# Altium schematic sheet 6 Pole Butterworth Bandpass Filter.SchDoc("6 Pole Butterworth Bandpass Filter")
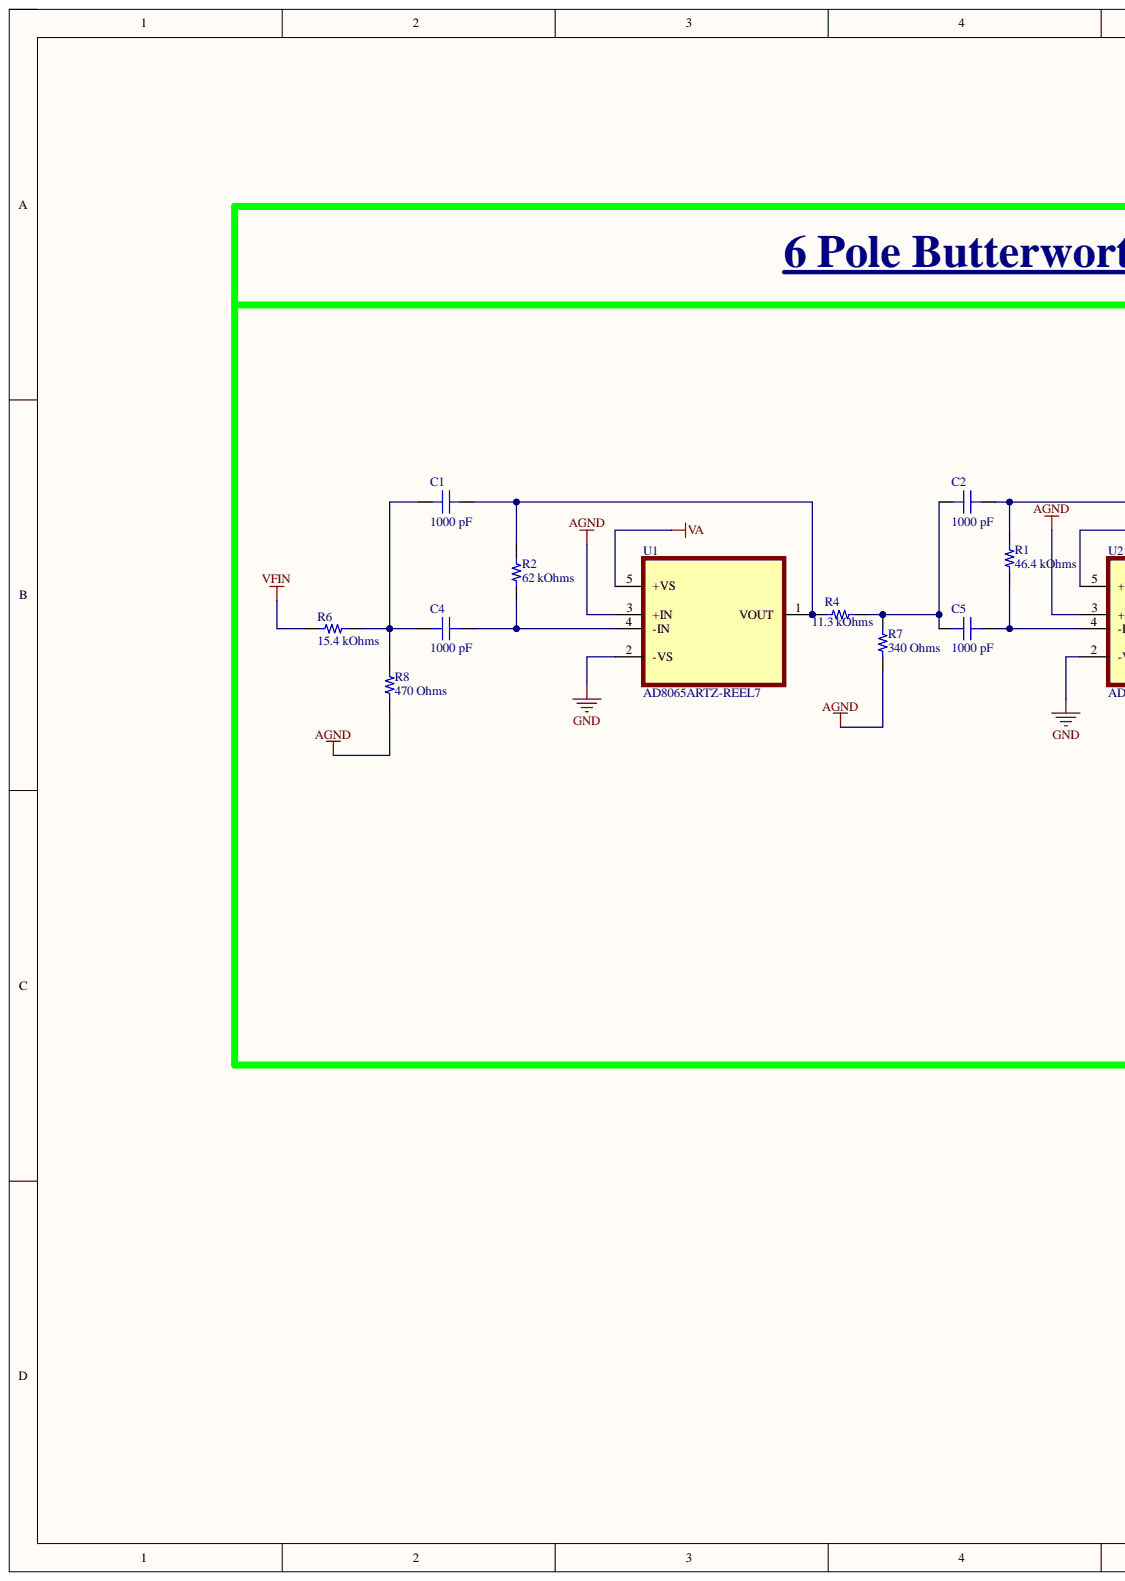
[diagram p0: 6 Pole Butterworth Bandpass Filter.SchDoc, left]
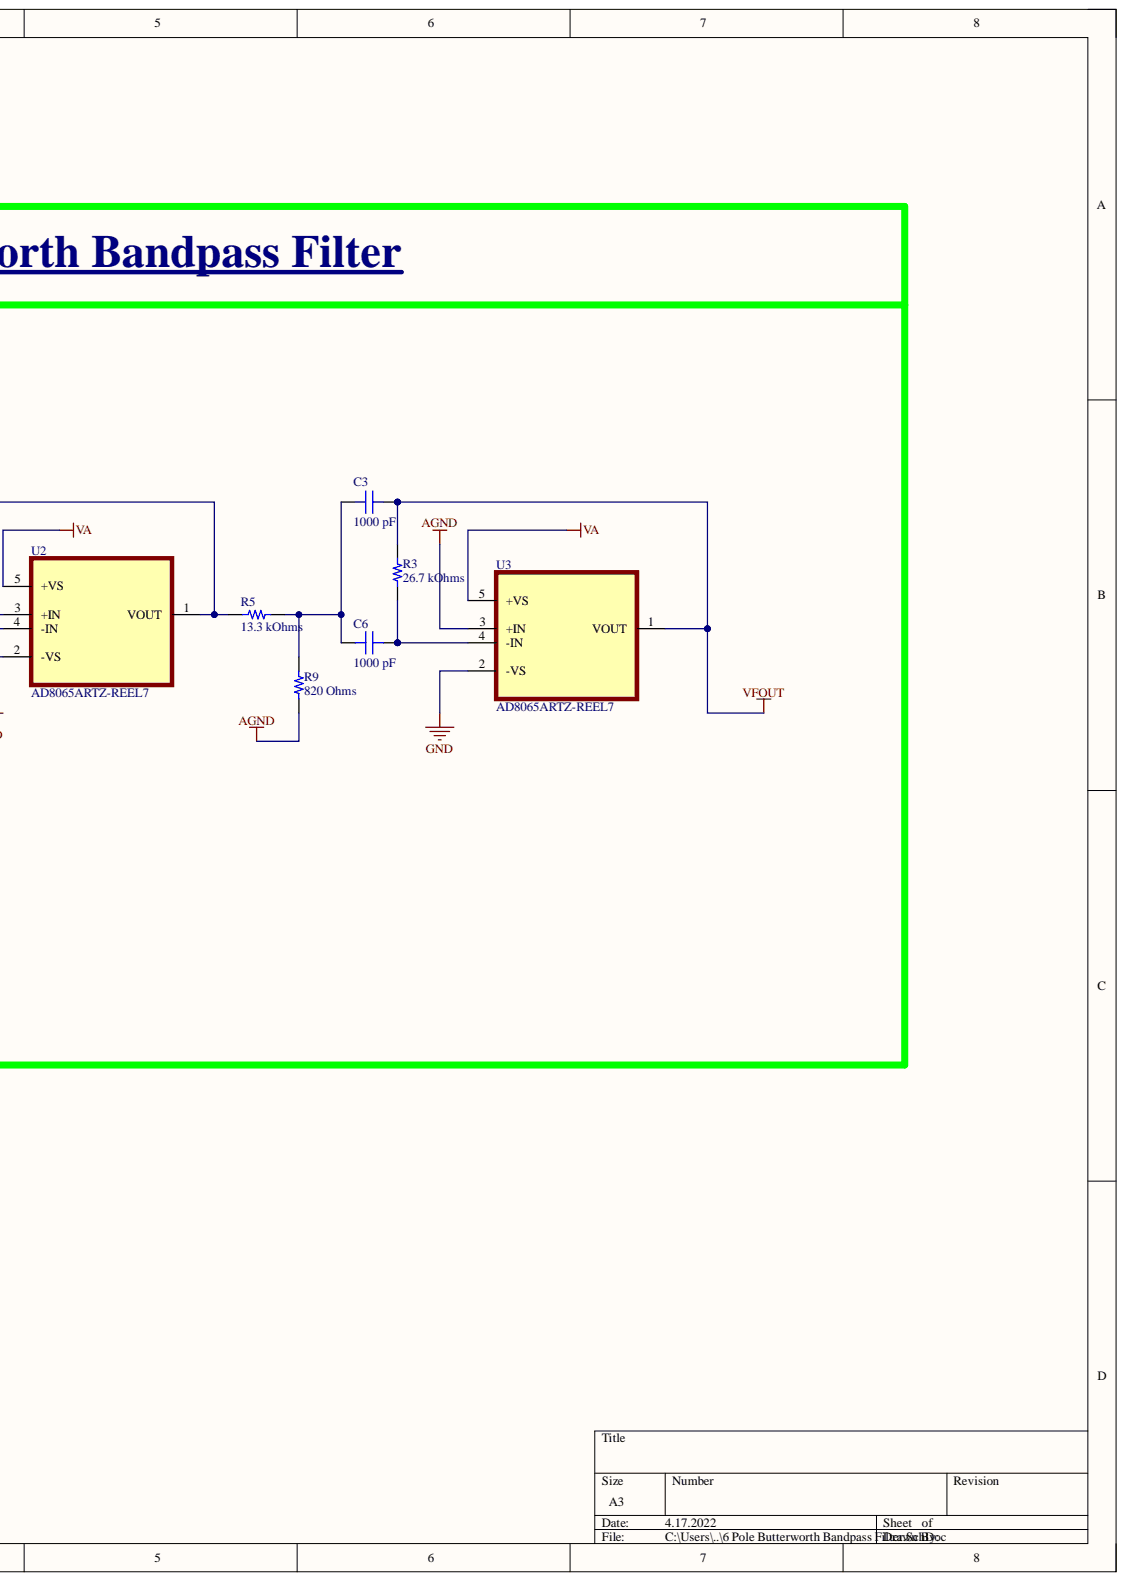
[diagram p1: 6 Pole Butterworth Bandpass Filter.SchDoc, right]

## Parts
C1  1000 pF  [CAP 0805_2012]
C2  1000 pF  [CAP 0805_2012]
C3  1000 pF  [CAP 0805_2012]
C4  1000 pF  [CAP 0805_2012]
C5  1000 pF  [CAP 0805_2012]
C6  1000 pF  [CAP 0805_2012]
R1  46.4 kOhms  [RES 0805_2012]
R2  62 kOhms  [RES 0805_2012]
R3  26.7 kOhms  [RES 0805_2012]
R4  11.3 kOhms  [RES 0805_2012]
R5  13.3 kOhms  [RES 1206_3216]
R6  15.4 kOhms  [RES 0805_2012]
R7  340 Ohms  [RES 1206_3216]
R8  470 Ohms  [RES 0805_2012]
R9  820 Ohms  [RES 0805_2012]
U1  AD8065ARTZ-REEL7  [SOT95P290X145-5N]
U2  AD8065ARTZ-REEL7  [SOT95P290X145-5N]
U3  AD8065ARTZ-REEL7  [SOT95P290X145-5N]

## Nets
AGND: R7.1 R8.1 R9.1 U1.3(+IN) U2.3(+IN) U3.3(+IN)
GND: U1.2(-VS) U2.2(-VS) U3.2(-VS)
VA: U1.5(+VS) U2.5(+VS) U3.5(+VS)
VFIN: R6.1
VFOUT: C3.2 R3.2 U3.1(VOUT)
NetC1_1: C1.1 C4.1 R6.2 R8.2
NetC1_2: C1.2 R2.2 R4.1 U1.1(VOUT)
NetC2_1: C2.1 C5.1 R4.2 R7.2
NetC2_2: C2.2 R1.2 R5.1 U2.1(VOUT)
NetC3_1: C3.1 C6.1 R5.2 R9.2
NetC4_2: C4.2 R2.1 U1.4(-IN)
NetC5_2: C5.2 R1.1 U2.4(-IN)
NetC6_2: C6.2 R3.1 U3.4(-IN)

## Library data of the parts
C1-C6 = C2012C0G2A102J060AA: CAP CER 1000PF 100V C0G 0805; manufacturer: TDK Corporation; Database Table Name: Capacitors - Ceramic - 0805; Temperature Coefficient: C0G, NP0; Tolerance: ±5%; Applications: General Purpose; Capacitance: 1000 pF; Component Kind: Standard; componentlink1description: Datasheet; componentlink1url: https://product.tdk.com/info/en/catalog/datasheets/mlcc_commercial_midvoltage_en.pdf?ref_disty=digikey; componentlink2description: DigiKey Link; componentlink2url: https://www.digikey.com/en/products/detail/tdk-corporation/C2012C0G2A102J060AA/789714; Device Package: 0805 (2012 Metric); lastupdated: 12.04.2022 22:11:39; Library Name: Celestial Altium Library - altium_library.DbLib; Lifecycle Status: Active; Minimum Order: 1; Mounting Type: Surface Mount, MLCC; Operating Temperature: -55°C ~ 125°C; Package / Case: 0805 (2012 Metric); packaging: TapeAndReel; Part Number: C2012C0G2A102J060AA; Part Status: Active; partid: 3238; price: 0.01; series: C; Size / Dimension: 0.079" L x 0.049" W (2.00mm x 1.25mm); Supplier 1: DigiKey; Supplier Part Number 1: 445-2320-1-ND; Thickness (Max): 0.030" (0.75mm); Value: 1000 pF; Voltage - Rated: 100V
R1 = RT0805DRE0746K4L: RES SMD 46.4K OHM 0.5% 1/8W 0805; Manufacturer: Yageo; Database Table Name: Resistors - Surface Mount - 0805; Power (Watts): 0.125W, 1/8W; Temperature Coefficient: ±50ppm/°C; Component Kind: Standard; ComponentLink1Description: Datasheet; ComponentLink1URL: https://www.yageo.com/upload/media/product/productsearch/datasheet/rchip/PYu-RT_1-to-0.01_RoHS_L_11.pdf; ComponentLink2Description: DigiKey Link; ComponentLink2URL: https://www.digikey.com/en/products/detail/yageo/RT0805DRE0746K4L/1078715; Composition: Thin Film; Device Package: 0805 (2012 Metric); Height - Seated (Max): 0.024" (0.60mm); LastUpdated: 12.04.2022 22:40:28; Library Name: Celestial Altium Library - altium_library.DbLib; Lifecycle Status: Active; Minimum Order: 1; Number of Terminations: 2; Operating Temperature: -55°C ~ 155°C; Package / Case: 0805 (2012 Metric); Packaging: TapeAndReel; Part Number: RT0805DRE0746K4L; Part Status: Active; PartId: 31477; Price: 0.01; Resistance: 46.4 kOhms; Series: RT; Size / Dimension: 0.079" L x 0.049" W (2.00mm x 1.25mm); Supplier 1: DigiKey; Supplier Device Package: 0805; Supplier Part Number 1: 311-2866-1-ND; Tolerance: ±0.5%; Value: 46.4 kOhms
R2 = CPF0805B62KE1: RES SMD 62K OHM 0.1% 1/10W 0805; Manufacturer: TE Connectivity Passive Product; Database Table Name: Resistors - Surface Mount - 0805; Power (Watts): 0.1W, 1/10W; Temperature Coefficient: ±25ppm/°C; Component Kind: Standard; ComponentLink1Description: Datasheet; ComponentLink1URL: https://www.te.com/commerce/DocumentDelivery/DDEController?Action=srchrtrv&DocNm=1773200&DocType=DS&DocLang=English; ComponentLink2Description: DigiKey Link; ComponentLink2URL: https://www.digikey.com/en/products/detail/te-connectivity-passive-product/CPF0805B62KE1/2382068; Composition: Thin Film; Device Package: 0805 (2012 Metric); Height - Seated (Max): 0.026" (0.65mm); LastUpdated: 12.04.2022 22:40:19; Library Name: Celestial Altium Library - altium_library.DbLib; Lifecycle Status: Active; Minimum Order: 1; Number of Terminations: 2; Operating Temperature: -55°C ~ 155°C; Package / Case: 0805 (2012 Metric); Packaging: TapeAndReel; Part Number: CPF0805B62KE1; Part Status: Active; PartId: 34241; Price: 0.13; Resistance: 62 kOhms; Series: CPF, Neohm; Size / Dimension: 0.079" L x 0.049" W (2.00mm x 1.25mm); Supplier 1: DigiKey; Supplier Device Package: 0805; Supplier Part Number 1: A103230CT-ND; Tolerance: ±0.1%; Value: 62 kOhms
R3 = ERJ-6ENF2672V: RES SMD 26.7K OHM 1% 1/8W 0805; Manufacturer: Panasonic Electronic Components; Database Table Name: Resistors - Surface Mount - 0805; Power (Watts): 0.125W, 1/8W; Temperature Coefficient: ±100ppm/°C; Component Kind: Standard; ComponentLink1Description: Datasheet; ComponentLink1URL: https://industrial.panasonic.com/cdbs/www-data/pdf/RDA0000/AOA0000C304.pdf; ComponentLink2Description: DigiKey Link; ComponentLink2URL: https://www.digikey.com/en/products/detail/panasonic-electronic-components/ERJ-6ENF2672V/111557; Composition: Thick Film; Device Package: 0805 (2012 Metric); Features: Automotive AEC-Q200; Height - Seated (Max): 0.028" (0.70mm); LastUpdated: 12.04.2022 22:40:32; Library Name: Celestial Altium Library - altium_library.DbLib; Lifecycle Status: Active; Minimum Order: 1; Number of Terminations: 2; Operating Temperature: -55°C ~ 155°C; Package / Case: 0805 (2012 Metric); Packaging: TapeAndReel; Part Number: ERJ-6ENF2672V; Part Status: Active; PartId: 30267; Price: 0.01; Ratings: AEC-Q200; Resistance: 26.7 kOhms; Series: ERJ-6EN; Size / Dimension: 0.079" L x 0.049" W (2.00mm x 1.25mm); Supplier 1: DigiKey; Supplier Device Package: 0805; Supplier Part Number 1: P26.7KCCT-ND; Tolerance: ±1%; Value: 26.7 kOhms
R4 = RK73H2ATTD1132F: RES 11.3K OHM 1% 1/4W 0805; Manufacturer: KOA Speer Electronics, Inc.; Database Table Name: Resistors - Surface Mount - 0805; Power (Watts): 0.25W, 1/4W; Temperature Coefficient: ±100ppm/°C; Component Kind: Standard; ComponentLink1Description: Datasheet; ComponentLink1URL: http://www.koaspeer.com/catimages/Products/RK73H/RK73H.pdf; ComponentLink2Description: DigiKey Link; ComponentLink2URL: https://www.digikey.com/en/products/detail/koa-speer-electronics-inc/RK73H2ATTD1132F/10233627; Composition: Thick Film; Device Package: 0805 (2012 Metric); Features: Automotive AEC-Q200, Moisture Resistant; Height - Seated (Max): 0.024" (0.60mm); LastUpdated: 12.04.2022 22:40:20; Library Name: Celestial Altium Library - altium_library.DbLib; Lifecycle Status: Active; Minimum Order: 1; Number of Terminations: 2; Operating Temperature: -55°C ~ 155°C; Package / Case: 0805 (2012 Metric); Packaging: TapeAndReel; Part Number: RK73H2ATTD1132F; Part Status: Active; PartId: 33915; Price: 0.01; Ratings: AEC-Q200; Resistance: 11.3 kOhms; Series: RK73H; Size / Dimension: 0.079" L x 0.049" W (2.00mm x 1.25mm); Supplier 1: DigiKey; Supplier Device Package: 0805; Supplier Part Number 1: 2019-RK73H2ATTD1132FCT-ND; Tolerance: ±1%; Value: 11.3 kOhms
R5 = RK73H2BTTD1332F: RES 13.3K OHM 1% 1/4W 1206; Manufacturer: KOA Speer Electronics, Inc.; Database Table Name: Resistors - Surface Mount - 1206; Power (Watts): 0.25W, 1/4W; Temperature Coefficient: ±100ppm/°C; Component Kind: Standard; ComponentLink1Description: Datasheet; ComponentLink1URL: http://www.koaspeer.com/catimages/Products/RK73H/RK73H.pdf; ComponentLink2Description: DigiKey Link; ComponentLink2URL: https://www.digikey.com/en/products/detail/koa-speer-electronics-inc/RK73H2BTTD1332F/10236178; Composition: Thick Film; Device Package: 1206 (3216 Metric); Features: Automotive AEC-Q200, Moisture Resistant; Height - Seated (Max): 0.028" (0.70mm); LastUpdated: 12.04.2022 22:34:22; Library Name: Celestial Altium Library - altium_library.DbLib; Lifecycle Status: Active; Minimum Order: 1; Number of Terminations: 2; Operating Temperature: -55°C ~ 155°C; Package / Case: 1206 (3216 Metric); Packaging: TapeAndReel; Part Number: RK73H2BTTD1332F; Part Status: Active; PartId: 27243; Price: 0.01; Ratings: AEC-Q200; Resistance: 13.3 kOhms; Series: RK73H; Size / Dimension: 0.126" L x 0.063" W (3.20mm x 1.60mm); Supplier 1: DigiKey; Supplier Device Package: 1206; Supplier Part Number 1: 2019-RK73H2BTTD1332FCT-ND; Tolerance: ±1%; Value: 13.3 kOhms
R6 = ERJ-6ENF1542V: RES SMD 15.4K OHM 1% 1/8W 0805; Manufacturer: Panasonic Electronic Components; Database Table Name: Resistors - Surface Mount - 0805; Power (Watts): 0.125W, 1/8W; Temperature Coefficient: ±100ppm/°C; Component Kind: Standard; ComponentLink1Description: Datasheet; ComponentLink1URL: https://industrial.panasonic.com/cdbs/www-data/pdf/RDA0000/AOA0000C304.pdf; ComponentLink2Description: DigiKey Link; ComponentLink2URL: https://www.digikey.com/en/products/detail/panasonic-electronic-components/ERJ-6ENF1542V/111510; Composition: Thick Film; Device Package: 0805 (2012 Metric); Features: Automotive AEC-Q200; Height - Seated (Max): 0.028" (0.70mm); LastUpdated: 12.04.2022 22:40:33; Library Name: Celestial Altium Library - altium_library.DbLib; Lifecycle Status: Active; Minimum Order: 1; Number of Terminations: 2; Operating Temperature: -55°C ~ 155°C; Package / Case: 0805 (2012 Metric); Packaging: TapeAndReel; Part Number: ERJ-6ENF1542V; Part Status: Active; PartId: 30250; Price: 0.01; Ratings: AEC-Q200; Resistance: 15.4 kOhms; Series: ERJ-6EN; Size / Dimension: 0.079" L x 0.049" W (2.00mm x 1.25mm); Supplier 1: DigiKey; Supplier Device Package: 0805; Supplier Part Number 1: P15.4KCCT-ND; Tolerance: ±1%; Value: 15.4 kOhms
R7 = ERJ-8ENF3400V: RES SMD 340 OHM 1% 1/4W 1206; Manufacturer: Panasonic Electronic Components; Database Table Name: Resistors - Surface Mount - 1206; Power (Watts): 0.25W, 1/4W; Temperature Coefficient: ±100ppm/°C; Component Kind: Standard; ComponentLink1Description: Datasheet; ComponentLink1URL: https://industrial.panasonic.com/cdbs/www-data/pdf/RDA0000/AOA0000C304.pdf; ComponentLink2Description: DigiKey Link; ComponentLink2URL: https://www.digikey.com/en/products/detail/panasonic-electronic-components/ERJ-8ENF3400V/88773; Composition: Thick Film; Device Package: 1206 (3216 Metric); Features: Automotive AEC-Q200; Height - Seated (Max): 0.028" (0.70mm); LastUpdated: 12.04.2022 22:34:11; Library Name: Celestial Altium Library - altium_library.DbLib; Lifecycle Status: Active; Minimum Order: 1; Number of Terminations: 2; Operating Temperature: -55°C ~ 155°C; Package / Case: 1206 (3216 Metric); Packaging: TapeAndReel; Part Number: ERJ-8ENF3400V; Part Status: Active; PartId: 29324; Price: 0.02; Ratings: AEC-Q200; Resistance: 340 Ohms; Series: ERJ-8EN; Size / Dimension: 0.126" L x 0.063" W (3.20mm x 1.60mm); Supplier 1: DigiKey; Supplier Device Package: 1206; Supplier Part Number 1: P340FCT-ND; Tolerance: ±1%; Value: 340 Ohms
R8 = CRGP0805F470R: RES 470 OHM 1% 1/3W 0805; Manufacturer: TE Connectivity Passive Product; Database Table Name: Resistors - Surface Mount - 0805; Power (Watts): 0.333W, 1/3W; Temperature Coefficient: ±100ppm/°C; Component Kind: Standard; ComponentLink1Description: Datasheet; ComponentLink1URL: https://www.te.com/commerce/DocumentDelivery/DDEController?Action=srchrtrv&DocNm=9-1773463-9&DocType=DS&DocLang=English; ComponentLink2Description: DigiKey Link; ComponentLink2URL: https://www.digikey.com/en/products/detail/te-connectivity-passive-product/CRGP0805F470R/8577075; Composition: Thick Film; Device Package: 0805 (2012 Metric); Features: Pulse Withstanding; Height - Seated (Max): 0.026" (0.65mm); LastUpdated: 12.04.2022 22:40:38; Library Name: Celestial Altium Library - altium_library.DbLib; Lifecycle Status: Active; Minimum Order: 1; Number of Terminations: 2; Operating Temperature: -55°C ~ 155°C; Package / Case: 0805 (2012 Metric); Packaging: TapeAndReel; Part Number: CRGP0805F470R; Part Status: Active; PartId: 28801; Price: 0.03; Resistance: 470 Ohms; Series: CRGP; Size / Dimension: 0.079" L x 0.049" W (2.00mm x 1.25mm); Supplier 1: DigiKey; Supplier Device Package: 0805; Supplier Part Number 1: A130473CT-ND; Tolerance: ±1%; Value: 470 Ohms
R9 = CR0805-JW-821EPF: RES 0805 820R 5% 125MW TC200 LOW; Manufacturer: Bourns Inc.; Database Table Name: Resistors - Surface Mount - 0805; Power (Watts): 0.125W, 1/8W; Temperature Coefficient: ±200ppm/°C; Component Kind: Standard; ComponentLink1Description: Datasheet; ComponentLink1URL: https://www.bourns.com/docs/Product-Datasheets/CR-PF.pdf; ComponentLink2Description: DigiKey Link; ComponentLink2URL: https://www.digikey.com/en/products/detail/bourns-inc/CR0805-JW-821EPF/8572952; Composition: Thick Film; Device Package: 0805 (2012 Metric); Height - Seated (Max): 0.024" (0.60mm); LastUpdated: 12.04.2022 22:39:27; Library Name: Celestial Altium Library - altium_library.DbLib; Lifecycle Status: Active; Minimum Order: 1; Number of Terminations: 2; Operating Temperature: -55°C ~ 155°C; Package / Case: 0805 (2012 Metric); Packaging: TapeAndReel; Part Number: CR0805-JW-821EPF; Part Status: Active; PartId: 46969; Price: 0.01; Resistance: 820 Ohms; Series: CR-PF; Size / Dimension: 0.079" L x 0.049" W (2.00mm x 1.25mm); Supplier 1: DigiKey; Supplier Device Package: 0805; Supplier Part Number 1: CR0805-JW-821EPFCT-ND; Tolerance: ±5%; Value: 820 Ohms
U1-U3 = AD8065ARTZ-REEL7: Voltage Feedback Amplifier 1 Circuit Rail-to-Rail SOT-23-5; MF: Analog Devices; Availability: Unavailable; Component Kind: Standard; DigiKey-Purchase-URL: https://snapeda.com/shop?store=DigiKey&id=385700; Library Name: AD8065ARTZ-REEL7.IntLib; MP: AD8065ARTZ-REEL7; Package: SOT-23-5 Analog Devices; Price: None; SnapEDA_Link: https://www.snapeda.com/parts/AD8065ARTZ-REEL7/Analog%20Devices%20Inc./view-part/385700/?ref=snap
